# Altium schematic sheet [06] Data IN_OUT.SchDoc
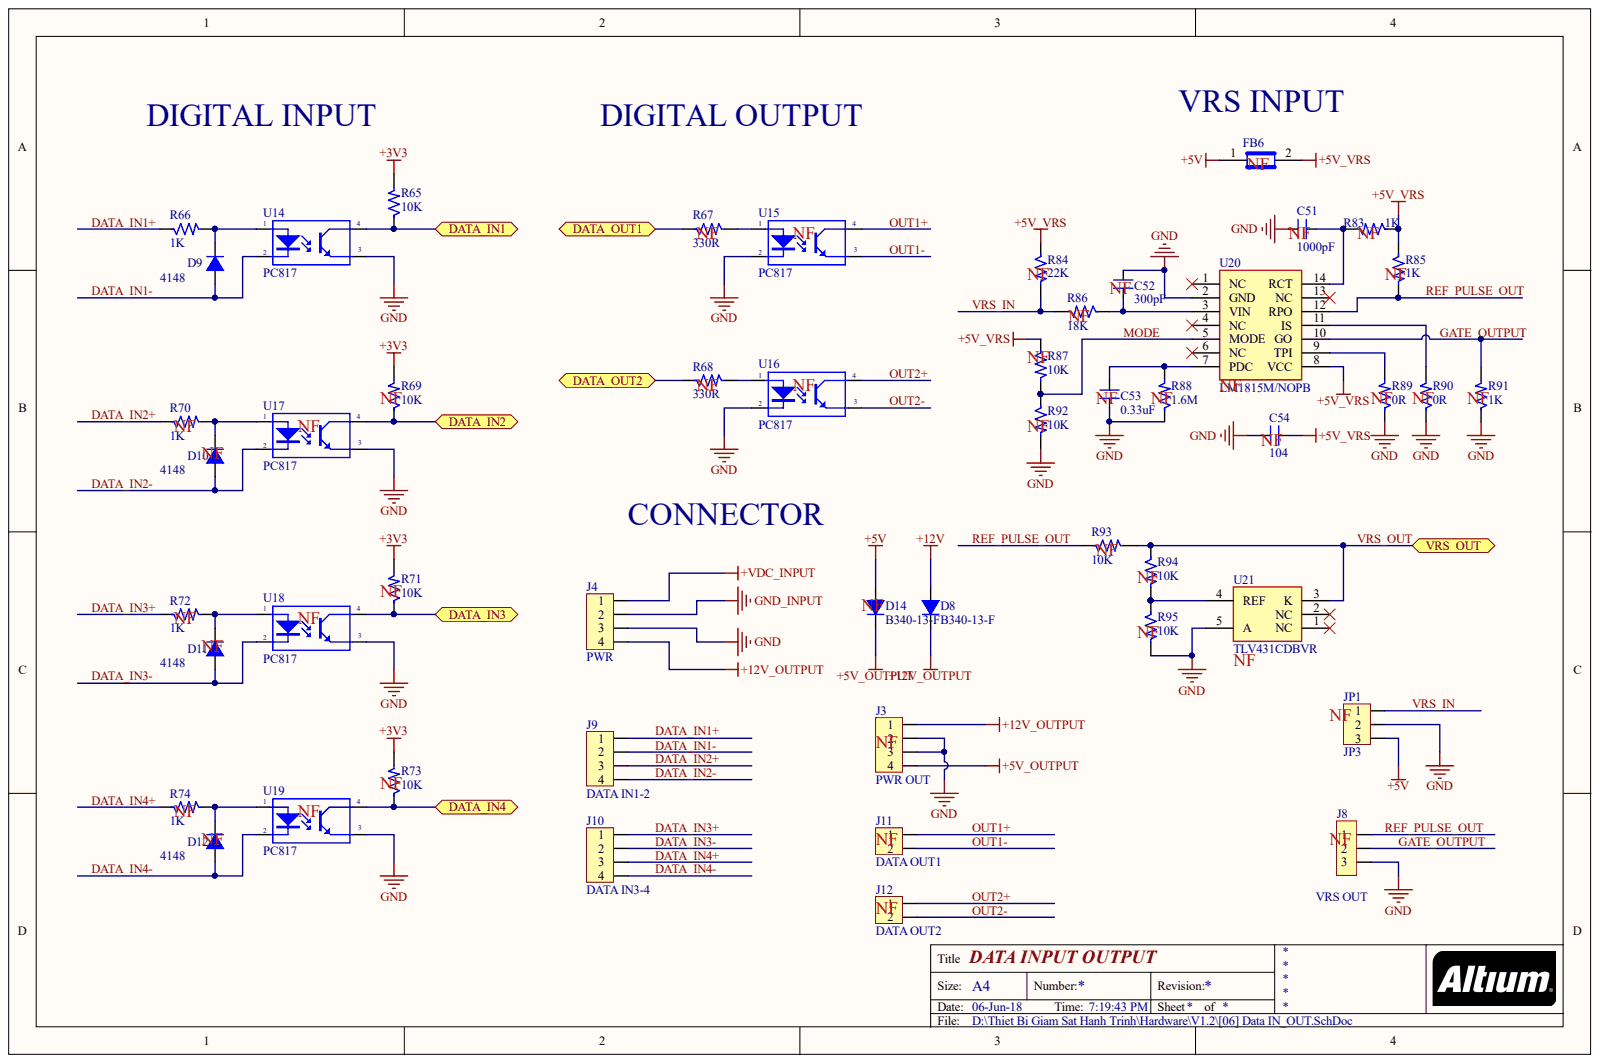
[diagram p0: [06] Data IN_OUT.SchDoc]

## Parts
C51  1000pF  [C0603 SMT]
C52  300pF  [C0603 SMT]
C53  0.33uF  [C0603 SMT]
C54  104  [C0603 SMT]
D8  B340-13-F  [DO-214AB SMA]
D9  4148  [DO-4148 SMD]
D10  4148  [DO-4148 SMD]
D11  4148  [DO-4148 SMD]
D12  4148  [DO-4148 SMD]
D14  B340-13-F  [DO-214AB SMA]
FB6  BLM21PG600SN1D  [R0805]  (value not drawn)
J3  PWR OUT  [CON_2.54mm_4]
J4  PWR  [Hed4V_3.96]
J8  VRS OUT  [JP3]
J9  DATA IN1-2  [CON_2.54mm_4]
J10  DATA IN3-4  [CON_2.54mm_4]
J11  DATA OUT1  [CON_2.54mm_2]
J12  DATA OUT2  [CON_2.54mm_2]
JP1  JP3  [CON_2.54mm_3]
R65  10K  [R0603 -SMT]
R66  1K  [R0603 -SMT]
R67  330R  [R0603 -SMT]
R68  330R  [R0603 -SMT]
R69  10K  [R0603 -SMT]
R70  1K  [R0603 -SMT]
R71  10K  [R0603 -SMT]
R72  1K  [R0603 -SMT]
R73  10K  [R0603 -SMT]
R74  1K  [R0603 -SMT]
R83  1K  [R0603 -SMT]
R84  22K  [R0603 -SMT]
R85  1K  [R0603 -SMT]
R86  18K  [R0603 -SMT]
R87  10K  [R0603 -SMT]
R88  1.6M  [R0603 -SMT]
R89  0R  [R0603 -SMT]
R90  0R  [R0603 -SMT]
R91  1K  [R0603 -SMT]
R92  10K  [R0603 -SMT]
R93  10K  [R0603 -SMT]
R94  10K  [R0603 -SMT]
R95  10K  [R0603 -SMT]
U14  PC817  [DIP4/W0.3]
U15  PC817  [DIP4/W0.3]
U16  PC817  [DIP4/W0.3]
U17  PC817  [DIP4/W0.3]
U18  PC817  [DIP4/W0.3]
U19  PC817  [DIP4/W0.3]
U20  LM1815M/NOPB  [SOIC-D14]
U21  TLV431CDBVR  [SOT23-5 DBV]

## Ports
DATA_IN1, DATA_IN2, DATA_IN3, DATA_IN4, DATA_OUT1, DATA_OUT2, VRS_OUT

## Nets
+3V3: R65.2 R69.2 R71.2 R73.2
+5V: D14.1 FB6.1 JP1.3
+5V_OUTPUT: D14.2 J3.4
+5V_VRS: C54.1 FB6.2 R83.1 R84.1 R85.1 R87.1 U20.8(VCC)
+12V: D8.1
+12V_OUTPUT: D8.2 J3.1 J4.4
+VDC_INPUT: J4.1
GND: C51.2 C52.2 C53.1 C54.2 J3.2(NF) J3.3 J4.3 J8.3 JP1.2 R88.1 R89.1 R90.1 R91.1 R92.2 R95.2 U14.3 U15.2 U16.2 U17.3 U18.3 U19.3 U20.2(GND) U21.5(A)
GND_INPUT: J4.2
DATA_IN1: R65.1 U14.4 ; port DATA_IN1
DATA_IN1+: J9.1 R66.1
DATA_IN1-: D9.1 J9.2 U14.2
DATA_IN2: R69.1 U17.4 ; port DATA_IN2
DATA_IN2+: J9.3 R70.1
DATA_IN2-: D10.1 J9.4 U17.2
DATA_IN3: R71.1 U18.4 ; port DATA_IN3
DATA_IN3+: J10.1 R72.1
DATA_IN3-: D11.1 J10.2 U18.2
DATA_IN4: R73.1 U19.4 ; port DATA_IN4
DATA_IN4+: J10.3 R74.1
DATA_IN4-: D12.1 J10.4 U19.2
DATA_OUT1: R67.1 ; port DATA_OUT1
DATA_OUT2: R68.1 ; port DATA_OUT2
GATE_OUTPUT: J8.2 R91.2 U20.10(GO)
MODE: R87.2 R92.1 U20.5(MODE)
OUT1+: J11.1 U15.4
OUT1-: J11.2 U15.3
OUT2+: J12.1 U16.4
OUT2-: J12.2 U16.3
REF_PULSE_OUT: J8.1 R85.2 R93.1 U20.12(RPO)
VRS_IN: JP1.1 R84.2 R86.2
VRS_OUT: R93.2 R94.1 U21.3(K) ; port VRS_OUT
NetC51_1: C51.1 R83.2 U20.14(RCT)
NetC52_1: C52.1 R86.1 U20.3(VIN)
NetC53_2: C53.2 R88.2 U20.7(PDC)
NetD9_2: D9.2 R66.2 U14.1
NetD10_2: D10.2 R70.2 U17.1(NF)
NetD11_2: D11.2 R72.2 U18.1(NF)
NetD12_2: D12.2 R74.2 U19.1(NF)
NetR67_2: R67.2 U15.1(NF)
NetR68_2: R68.2 U16.1(NF)
NetR89_2: R89.2 U20.9(TPI)
NetR90_2: R90.2 U20.11(IS)
NetR94_2: R94.2 R95.1 U21.4(REF)

Not connected: U20 1(NC) 4(NC) 6(NC) 13(NC); U21 1(NC) 2(NC)

## Library data of the parts
C51-C54 = CM: Cap SMD; Value: 103; Component Kind: Standard; Library Name: khapham.IntLib
D8-D12 D14 = D1A: Diode 1A; Value: 1N4007; Component Kind: Standard; Library Name: khapham.IntLib
FB6 = Ferrite Bead: Component Kind: Standard; Description: Ferrite Bead; Library Name: khapham.IntLib
J3 J9 J10 = Con4: Connector 4; Component Kind: Standard; Value: Connector 4; Library Name: khapham.IntLib
J4 = Hed4: Header 4 (Green); Component Kind: Standard; Value: Header 4; Library Name: khapham.IntLib
J8 = JP3: Jumper 3; Value: J3; Component Kind: Standard; Library Name: khapham.IntLib
J11 J12 = Con2: Connector 2; Component Kind: Standard; Value: Connector 2; Library Name: khapham.IntLib
JP1 = Con3: Connector 3; Component Kind: Standard; Value: Connector 3; Library Name: khapham.IntLib
R65 R67-R69 R71 R73 R84 R86-R90 R92-R95 = RM: Resistor SMD; Value: 1K; Component Kind: Standard; Library Name: khapham.IntLib
R66 R70 R72 R74 R83 R85 R91 = RM: Resistor SMD; Component Kind: Standard; Value: 1K; Library Name: khapham.IntLib
U14-U19 = PC817: Single Opto; Component Kind: Standard; Value: PC817; Library Name: khapham.IntLib
U20 = LM1815: IC AMP ADAPTIV VAR SENSOR; Component Kind: Standard; Library Name: khapham.IntLib
U21 = TLV431: VREF SHUNT ADJ SOT23-5; Component Kind: Standard; Library Name: khapham.IntLib
